# Altium schematic sheet usb2uart.SchDoc
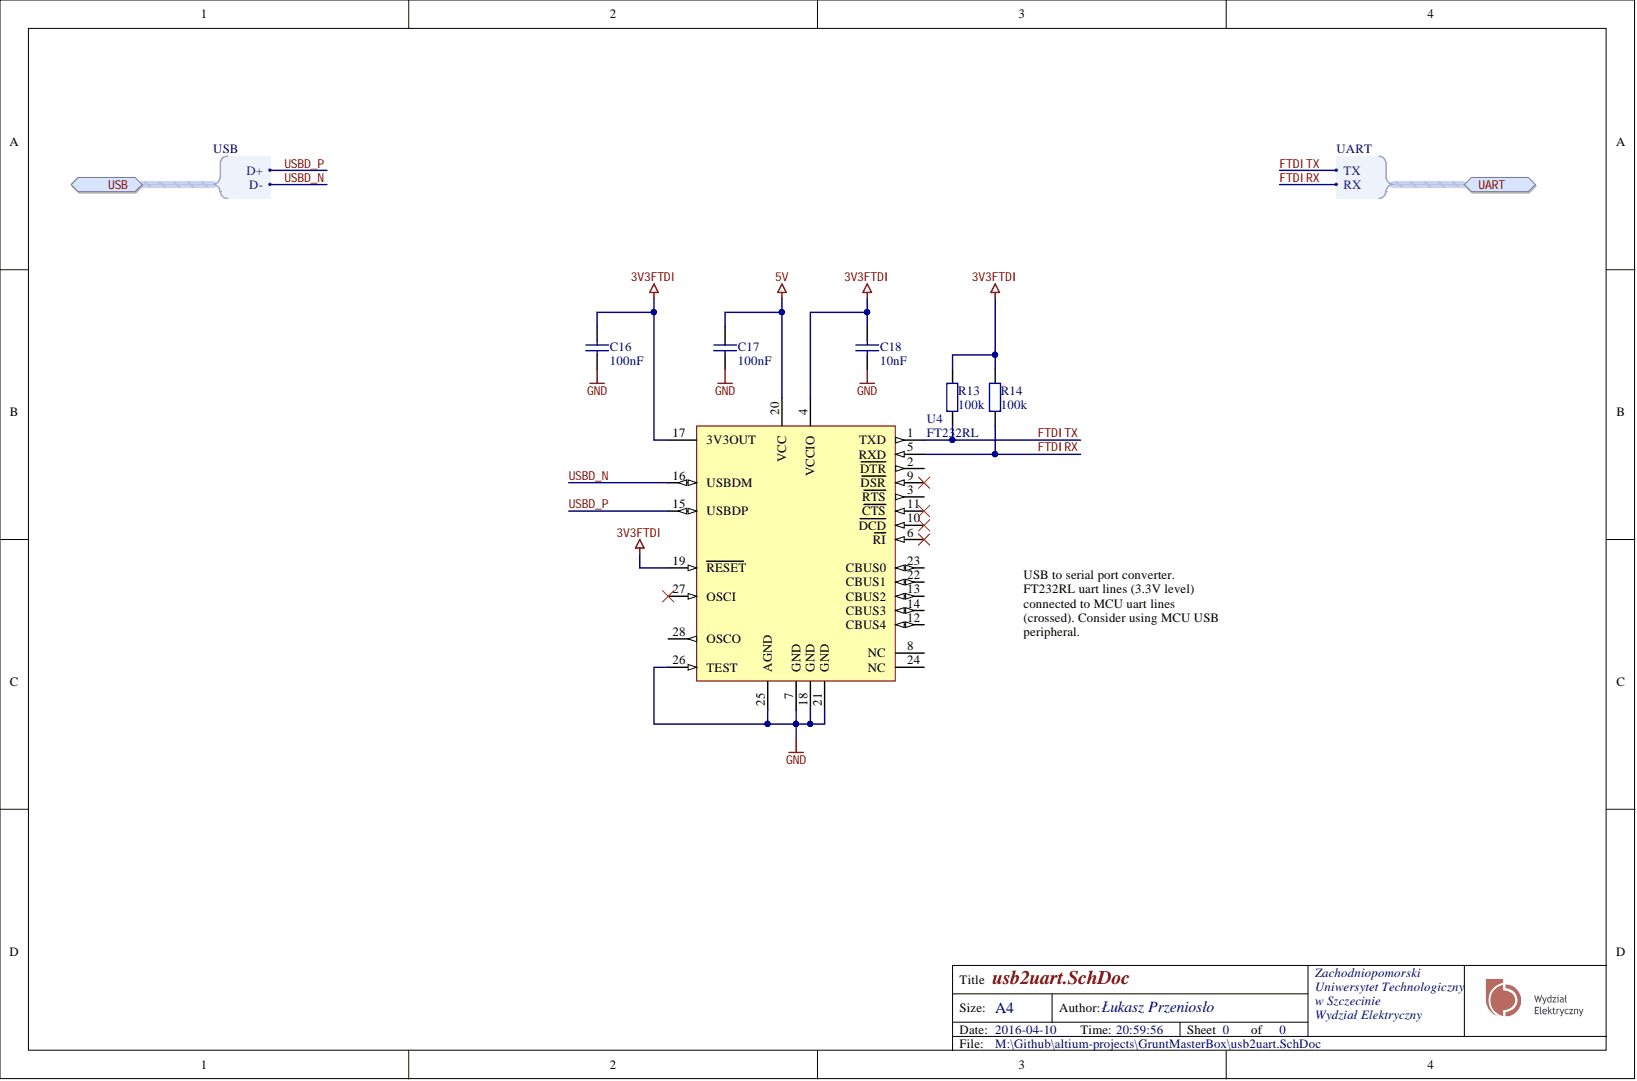
[diagram p0: usb2uart.SchDoc]

## Parts
C16  100nF  [CAPC1608N]
C17  100nF  [CAPC1608N]
C18  10nF  [CAPC1608N]
R13  100k  [RESC1608N]
R14  100k  [RESC1608N]
U4  FT232RL  [SSOP28]

## Ports
UART, UART.RX, UART.TX, USB, USB.D+, USB.D-

## Nets
3V3FTDI: C16.2 C18.2 R13.2 R14.2 U4.4(VCCIO) U4.17(3V3OUT) U4.19(RESET)
5V: C17.2 U4.20(VCC)
GND: C16.1 C17.1 C18.1 U4.7(GND) U4.18(GND) U4.21(GND) U4.25(AGND) U4.26(TEST)
FTDIRX: R14.1 U4.5(RXD) ; port UART
FTDITX: R13.1 U4.1(TXD) ; port UART
USBD_N: U4.16(USBDM) ; port USB
USBD_P: U4.15(USBDP) ; port USB

Not connected: U4 2(DTR) 3(RTS) 6(RI) 8(NC) 9(DSR) 10(DCD) 11(CTS) 12(CBUS4) 13(CBUS2) 14(CBUS3) 22(CBUS1) 23(CBUS0) 24(NC) 27(OSCI) 28(OSCO)

## Library data of the parts
C16-C18 = Capacitor: Component Kind: Standard; Description: Capacitor; Library Name: Discrete.SchLib; Signal Integrity: Cap; Simulation: CAP
  C16 C17: Value: 100nF
  C18: Value: 10nF
R13 R14 = Resistor: Power: 0W; Tolerance: 5%; Component Kind: Standard; Description: Resistor; Library Name: Discrete.SchLib; Signal Integrity: Res; Simulation: RESISTOR; Value: 100k
U4 = COM-UART-FT232R-IL28: USB UART Asynchronous Serial Data Transfer Chip, SSOP-28, Tray; Component Kind: Standard; DatasheetVersion: ver 2.07, Jul-2010; PackageDescription: 28-Pin Small Outline Package 0.65 mm Pitch; PackageReference: SSOP-28; PackageVersion: 2010; Library Name: my_various_devices.SchLib
